# Altium schematic sheet 07-UARTBridge.SchDoc
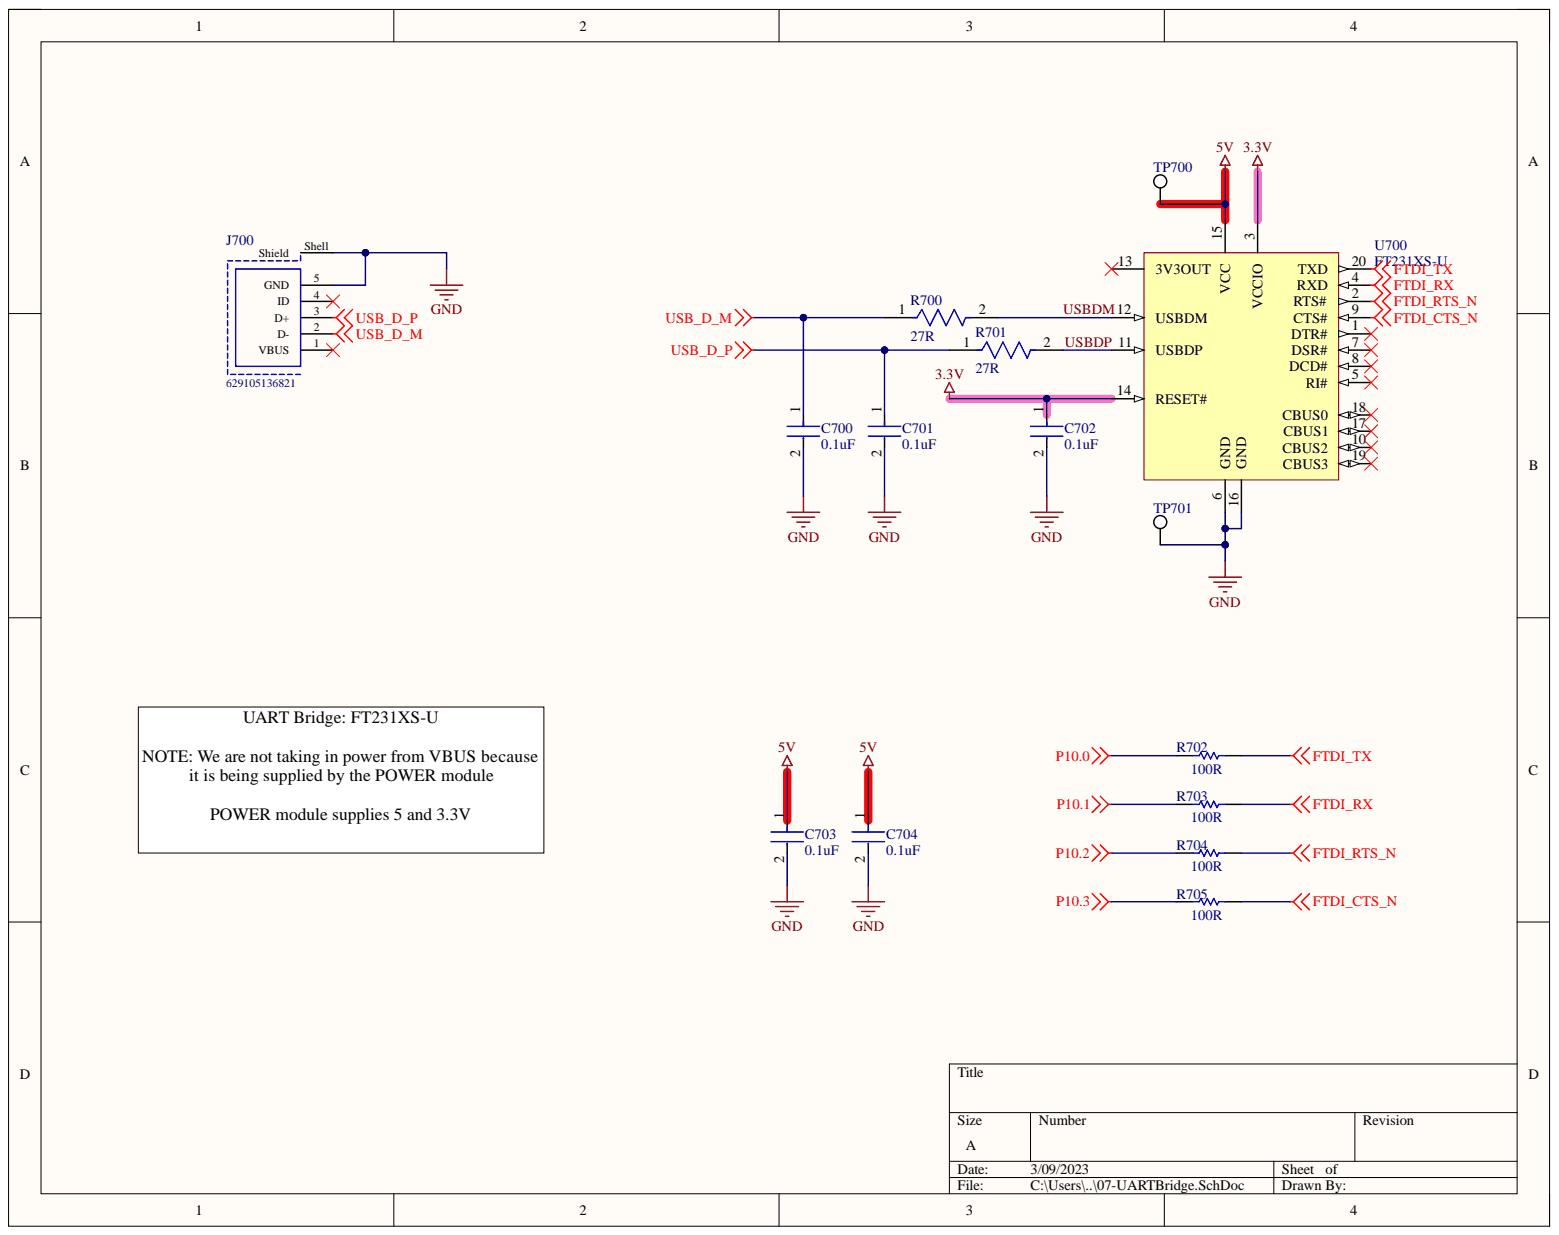
[diagram p0: 07-UARTBridge.SchDoc]

## Parts
C700  0.1uF  [FP-CL21-IPC_C]
C701  0.1uF  [FP-CL21-IPC_C]
C702  0.1uF  [FP-CL21-IPC_C]
C703  0.1uF  [FP-CL21-IPC_C]
C704  0.1uF  [FP-CL21-IPC_C]
J700  629105136821  [629105136821]
R700  27R  [FP-CRGCQ0805-IPC_C]
R701  27R  [FP-CRGCQ0805-IPC_C]
R702  100R  [RESC2013X60X40ML20T25]
R703  100R  [RESC2013X60X40ML20T25]
R704  100R  [RESC2013X60X40ML20T25]
R705  100R  [RESC2013X60X40ML20T25]
TP700  5019  [KSTN-5019_V]  (value not drawn)
TP701  5019  [KSTN-5019_V]  (value not drawn)
U700  FT231XS-U  [SSOP-20_L]

## Nets
3.3V: C702.1 U700.3(VCCIO) U700.14(RESET#)
5V: C703.1 C704.1 TP700.1 U700.15(VCC)
FTDI_CTS_N: R705.2 U700.9(CTS#)
FTDI_RTS_N: R704.2 U700.2(RTS#)
FTDI_RX: R703.2 U700.4(RXD)
FTDI_TX: R702.2 U700.20(TXD)
GND: C700.2 C701.2 C702.2 C703.2 C704.2 J700.5(GND) J700.Shell(Shield) TP701.1 U700.6(GND) U700.16(GND)
P10.0: R702.1
P10.1: R703.1
P10.2: R704.1
P10.3: R705.1
USB_D_M: C700.1 J700.2(D-) R700.1
USB_D_P: C701.1 J700.3(D+) R701.1
USBDM: R700.2 U700.12(USBDM)
USBDP: R701.2 U700.11(USBDP)

Not connected: J700 1(VBUS) 4(ID); U700 1(DTR#) 5(RI#) 7(DSR#) 8(DCD#) 10(CBUS2) 13(3V3OUT) 17(CBUS1) 18(CBUS0) 19(CBUS3)

## Library data of the parts
C700-C704 = CMP-2000-05017-2: CL21 Series 0805 100nF 50V ±10% Tolerance X7R Multilayer Ceramic Chip Capacitor; Capacitance: 100nF; Case Code (Imperial): 0805; Case Code (Metric): 2012; Case/Package: 0805; Component Kind: Standard; Contact Plating: Tin; Depth: 1.25mm; Dielectric: X7R; Height: 0.85mm; Lead Free: Lead Free; Length: 2mm; Library Name: Altium Content Vault; Material: Ceramic; Max Operating Temperature: 125°C; Min Operating Temperature: -55°C; Mount: Surface Mount; Packaging: Tape and Reel; Radiation Hardening: No; Thickness: 0.037inch; Tolerance: 10%; Voltage: 50V; Voltage Rating: 50V; Voltage Rating (DC): 50V; Width: 0.049inch
J700 = CMP-1502-03253-1: Micro USB 2.0 Type B Receptacle WR-COM, Horizontal, SMT, with Pads and Pegs; Manufacturer: Wurth Elektronik; Contact Resistance: 30mOhm; Rated Current: 1A; Working Voltage: 30VAC; Component Kind: Standard; Connector Type: MicroUSB2.0TypeB; Datasheet URL: https://katalog.we-online.de/em/datasheet/629105136821.pdf; Gender: Receptacle; Library Name: Altium Content Vault; Manufacturer URL: http://www.we-online.de; Mounting Technology: SMT; Operating Temperature: -40to105degC; Orientation: Horizontal; Package Reference: 629105136821; Packaging: TapeandReel; Part Number: 629105136821; Publisher: WurthElektronik
R700 R701 = CMP-03211-007904-1: CRGCQ0805 27Ω 0.125W ±200ppm/°C 1% 150V; Manufacturer: TE Connectivity; Manufacturer Part Number: CRGCQ0805F27R; Automotive Grade: Grade 323; Tolerance: 0.01; Ambient Temperature Range High: +70°C; Automotive: Yes; Category: Res; Component Kind: Standard; ComponentLink2Description: Datasheet; ComponentLink2URL: https://www.te.com/commerce/DocumentDelivery/DDEController?Action=showdoc&DocId=Data+Sheet%7F1773204-3%7F1%7Fpdf%7FEnglish%7FENG_DS_1773204-3_1.pdf%7F4-2176341-332; Composition: Thick Film; Device Class L1: Passive Components; Device Class L2: Resistors; Device Class L3: Chip SMD Resistors; Height: 0.65mm; Ipc Land Pattern Name: RESC200125X55; Lead Free: Yes; Library Name: Altium Content Vault; Mouser Description: Res General Purpose Thick Film 0805 27 Ohm 1% 1/8W ±200ppm/°C Molded Paper T/R; Octopart: https://octopart.com/crgcq0805f27r-te+connectivity-91018166; Package: CRGCQ0805; Power Rating: 0.125W; Resistance: 27Ω; RoHS: TRUE; Series: CRGCQ; Temperature: -55°C to +155°C; Temperature Coefficient: ±200ppm/°C; Temperature Range High: +155°C; Temperature Range Low: -55°C; Value: 27Ω; Voltage Rating: 150V
R702-R705 = CMP-2001-00580-1: Manufacturer: Panasonic; Part Number: ERA6AEB101V; Component Kind: Standard; Library Name: Altium Content Vault
TP700 TP701 = CMP-1672-00008-1: Test Point, 1 Position SMD, RoHS, Tape and Reel; Manufacturer 1: Keystone Electronics; Category: Connectors; Component Kind: Standard; ComponentLink1Description: Manufacturer URL; ComponentLink1URL: http://www.keyelco.com/; ComponentLink2Description: Datasheet; ComponentLink2URL: http://www.keyelco.com/product-pdf.cfm?p=1357; ComponentLink3Description: https://datasheet.ciiva.com/16318/m60-2p61-16318395.pdf?src-supplier=Future+Electronics; ComponentLink3URL: https://datasheet.ciiva.com/16318/m60-2p61-16318395.pdf?src-supplier=Future+Electronics; ComponentLink4Description: https://datasheet.ciiva.com/16885/70182998-16885945.pdf?src-supplier=Allied+Electronics; ComponentLink4URL: https://datasheet.ciiva.com/16885/70182998-16885945.pdf?src-supplier=Allied+Electronics; ComponentLink5Description: https://datasheet.ciiva.com/14848/m60-2p61-14848437.pdf?src-supplier=Digi-Key; ComponentLink5URL: https://datasheet.ciiva.com/14848/m60-2p61-14848437.pdf?src-supplier=Digi-Key; ComponentLink6Description: https://datasheet.ciiva.com/14848/m60-2p61-14848438.pdf?src-supplier=Digi-Key; ComponentLink6URL: https://datasheet.ciiva.com/14848/m60-2p61-14848438.pdf?src-supplier=Digi-Key; ComponentLink7Description: https://datasheet.ciiva.com/14848/m60-2p61-14848439.pdf?src-supplier=Digi-Key; ComponentLink7URL: https://datasheet.ciiva.com/14848/m60-2p61-14848439.pdf?src-supplier=Digi-Key; Contact Material: Bronze; Contact Plating: Silver; DatasheetVersion: 03/2014; Height: 0.09inch; Lead Free: Lead Free; Library Name: Altium Content Vault; Manufacturer Part Number 1: 5019; Material: Bronze; Mount: Surface Mount; Mounting Technology: Surface Mount; Number of Pins: 1; Package Quantity: 1000; PackageDescription: 1-Pin Surface Mount Device, Body 3.81 x 2.03 mm; PackageReference: 5019; Packaging: Tape and Reel; Pins: 1; Pricing 1: 1=0.34000, 25=0.27360, 100=0.22340, 250=0.20064, 500=0.19152 (USD); REACH SVHC: No SVHC; RoHS: Yes; RoHS Compliant: Yes; RoHSCompliant: True; Series: 5019; Stock 1: 1316816; Supplier 1: Digi-Key; Supplier Part Number 1: 36-5019CT-ND; Termination: SMD/SMT
U700 = CMP-0248-00015-2: USB to Full-Handshake UART Interface Chip, UHCI/OHCI/EHCI Compatible, USB 2.0 Compatible, -40 to +85 degC, 20-Pin SSOP, Pb-Free, Tube; Manufacturer: FTDI; Code_JEDEC: MO-137-AD; Component Kind: Standard; ComponentLink1Description: Manufacturer URL; ComponentLink1URL: http://www.ftdichip.com/; ComponentLink2Description: Datasheet; ComponentLink2URL: http://www.ftdichip.com/Support/Documents/DataSheets/ICs/DS_FT231X.pdf; ComponentLink3Description: FT231X Product Page; ComponentLink3URL: www.ftdichip.com/Products/ICs/FT231X.html; DatasheetVersion: ver 1.2, Feb-2013; Library Name: Altium Content Vault; PackageDescription: 20-Pin Small Outline Package, 8.6 x 3.9 mm Body, 0.635 mm Pitch; PackageReference: SSOP-20; PackageVersion: Feb-13; PartNumber: FT231XS-U; RevisionNote: DatasheetVersion updated to 1.2 Feb-2013
